# Altium schematic sheet 3_LPDDR4.schdoc(3_LPDDR4)
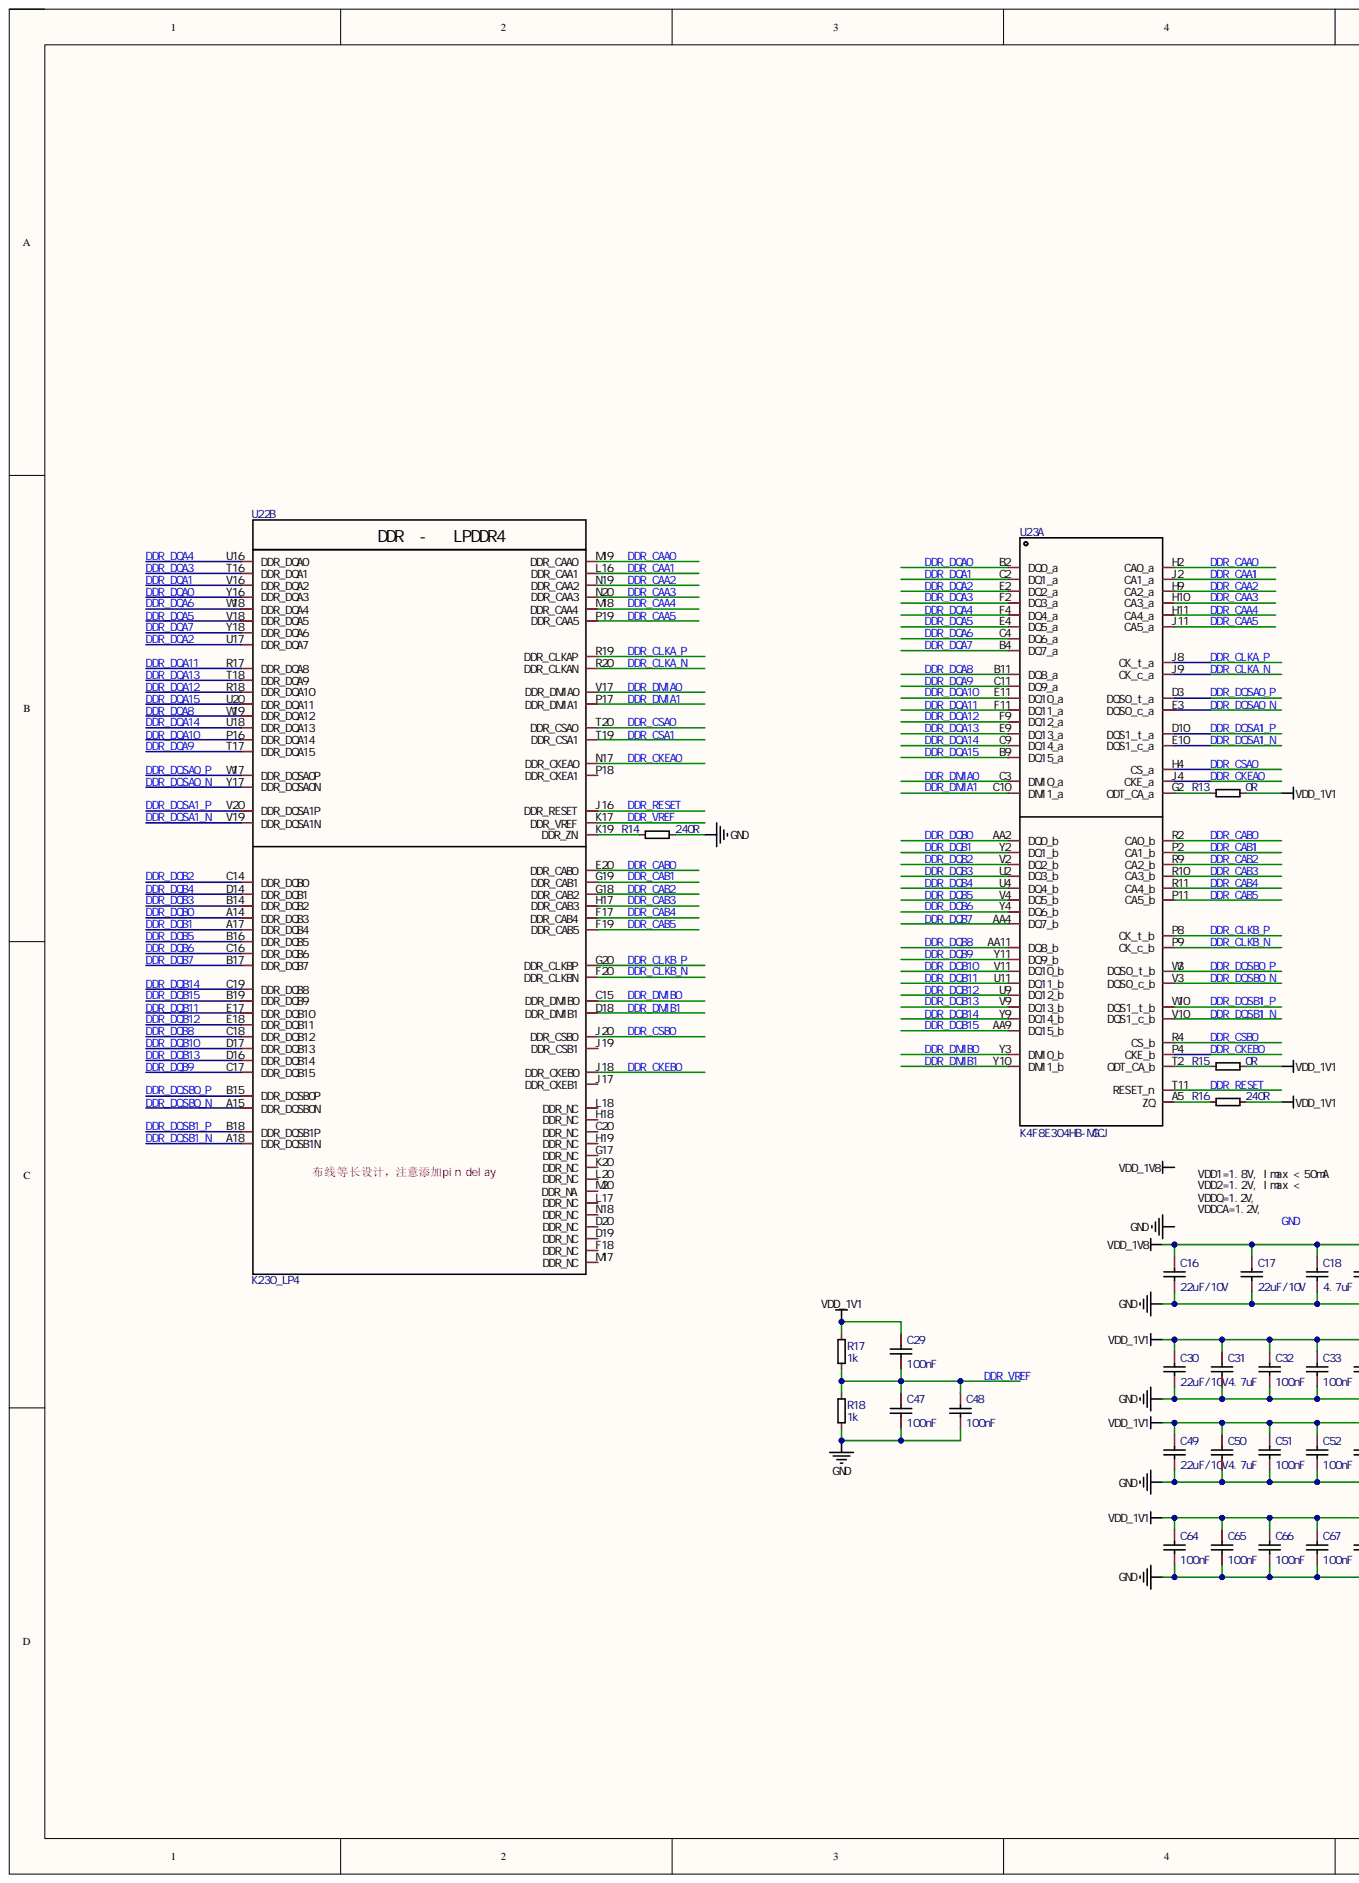
[diagram p0: 3_LPDDR4.schdoc, left]
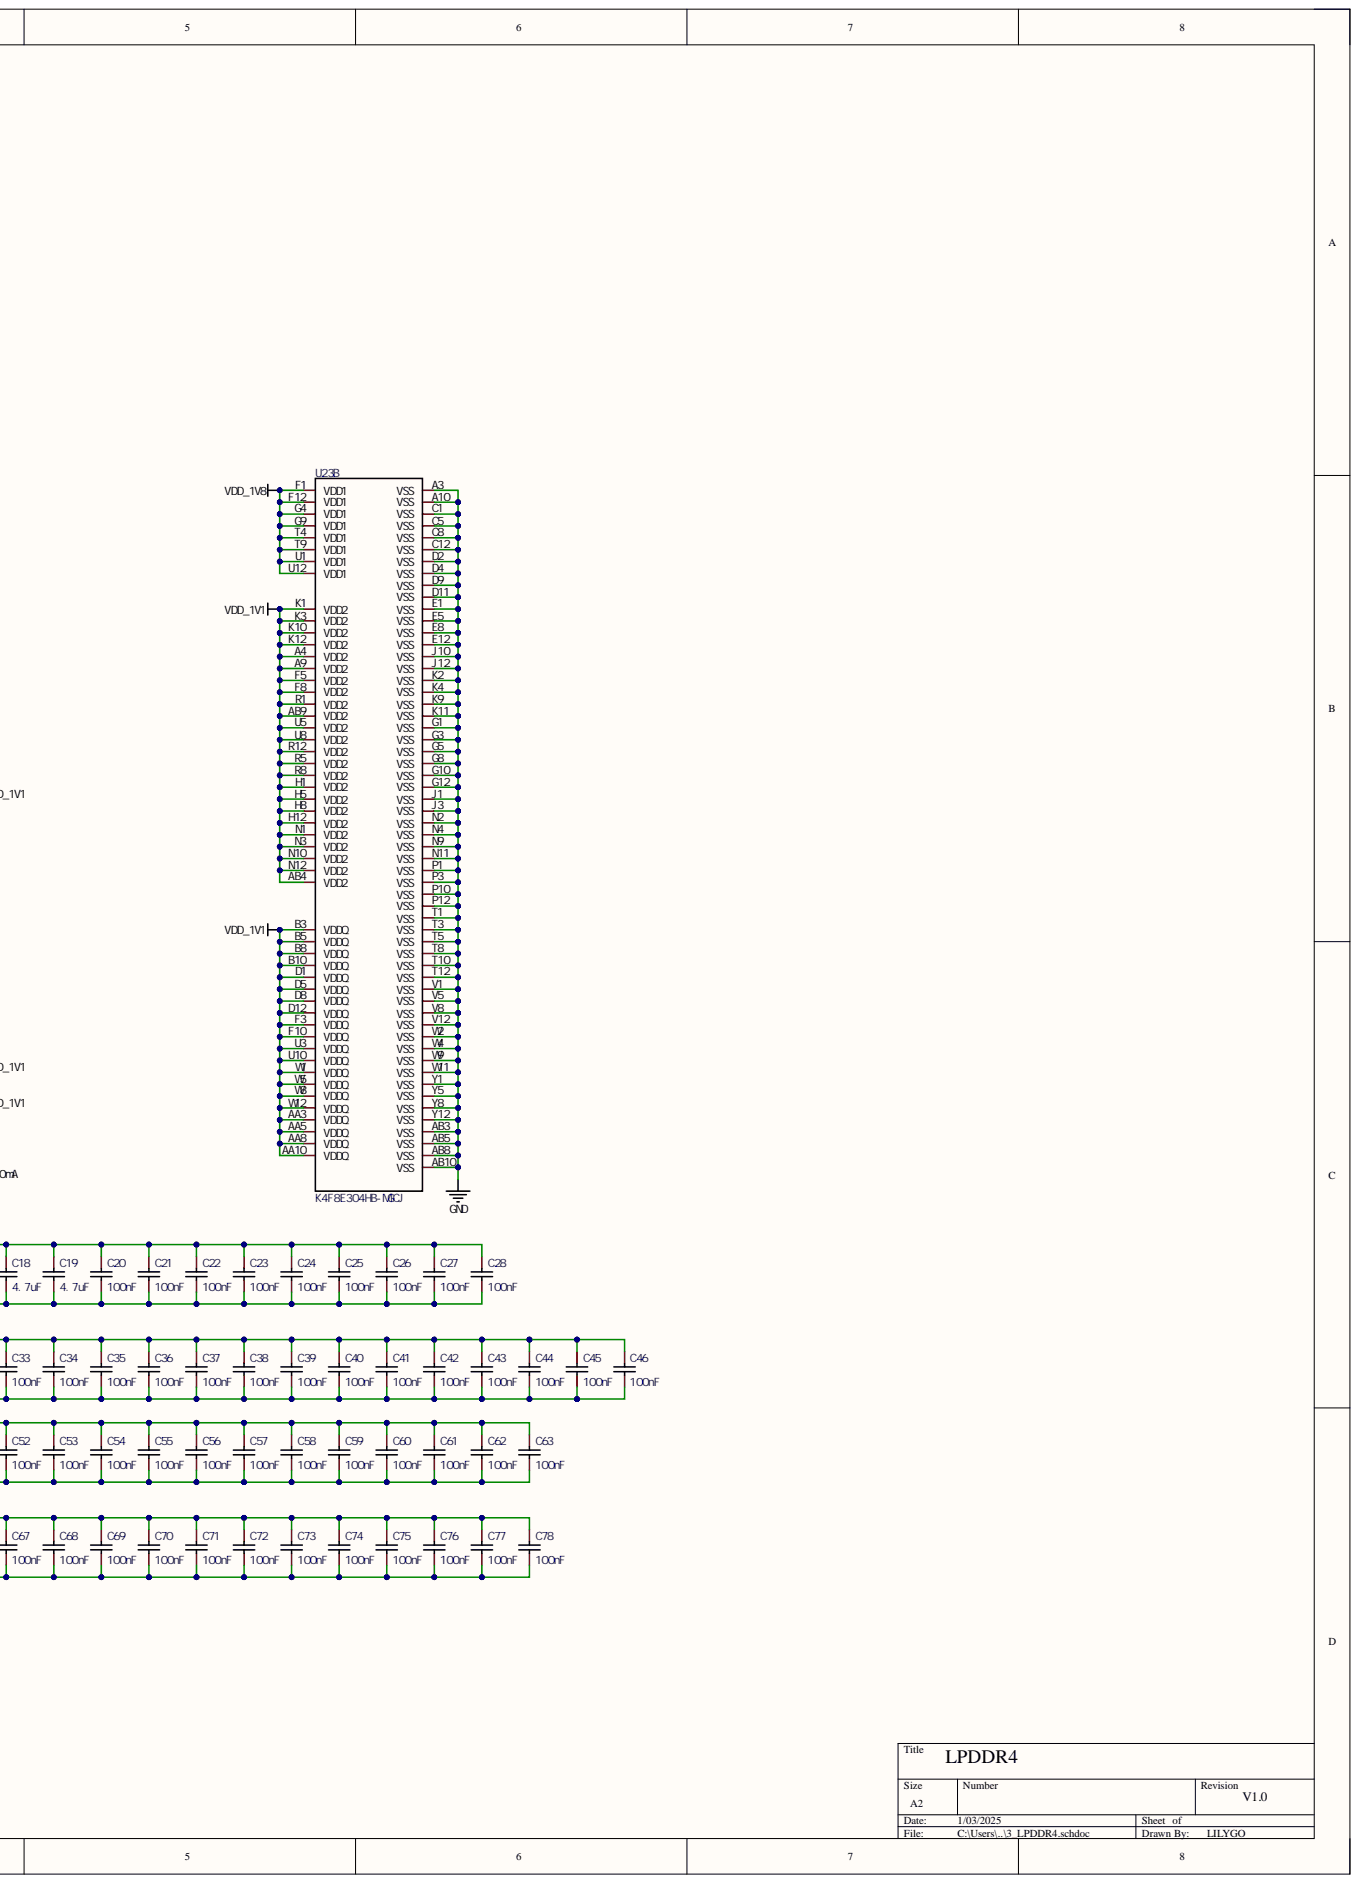
[diagram p1: 3_LPDDR4.schdoc, right]

## Parts
C16  22uF/10V  [c0603]
C17  22uF/10V  [c0603]
C18  4.7uF  [c0402]
C19  4.7uF  [c0402]
C20  100nF  [c0402]
C21  100nF  [c0402]
C22  100nF  [c0402]
C23  100nF  [c0402]
C24  100nF  [c0402]
C25  100nF  [C0201]
C26  100nF  [C0201]
C27  100nF  [C0201]
C28  100nF  [C0201]
C29  100nF  [c0402]
C30  22uF/10V  [c0603]
C31  4.7uF  [c0402]
C32  100nF  [C0201]
C33  100nF  [C0201]
C34  100nF  [C0201]
C35  100nF  [C0201]
C36  100nF  [C0201]
C37  100nF  [C0201]
C38  100nF  [C0201]
C39  100nF  [C0201]
C40  100nF  [C0201]
C41  100nF  [C0201]
C42  100nF  [C0201]
C43  100nF  [C0201]
C44  100nF  [C0201]
C45  100nF  [C0201]
C46  100nF  [C0201]
C47  100nF  [c0402]
C48  100nF  [C0201]
C49  22uF/10V  [c0603]
C50  4.7uF  [c0402]
C51  100nF  [C0201]
C52  100nF  [C0201]
C53  100nF  [C0201]
C54  100nF  [C0201]
C55  100nF  [C0201]
C56  100nF  [C0201]
C57  100nF  [C0201]
C58  100nF  [C0201]
C59  100nF  [C0201]
C60  100nF  [C0201]
C61  100nF  [C0201]
C62  100nF  [C0201]
C63  100nF  [C0201]
C64  100nF  [c0402]
C65  100nF  [c0402]
C66  100nF  [c0402]
C67  100nF  [c0402]
C68  100nF  [c0402]
C69  100nF  [c0402]
C70  100nF  [c0402]
C71  100nF  [c0402]
C72  100nF  [C0201]
C73  100nF  [C0201]
C74  100nF  [C0201]
C75  100nF  [C0201]
C76  100nF  [C0201]
C77  100nF  [C0201]
C78  100nF  [C0201]
R13  0R  [r0402]
R14  240R  [r0402]
R15  0R  [r0402]
R16  240R  [r0402]
R17  1k  [r0402]
R18  1k  [r0402]
U22  K230_LP4  [K230]  (part B)
U23  K4F8E304HB-MGCJ  [BGA-200_L15.0-W10.0_K4F8E304HB-MGCJ]  (parts A,B)

## Nets
VDD_1V1: C29.1 C30.1 C31.1 C32.1 C33.1 C34.1 C35.1 C36.1 C37.1 C38.1 C39.1 C40.1 C41.1 C42.1 C43.1 C44.1 C45.1 C46.1 C49.1 C50.1 C51.1 C52.1 C53.1 C54.1 C55.1 C56.1 C57.1 C58.1 C59.1 C60.1 C61.1 C62.1 C63.1 C64.1 C65.1 C66.1 C67.1 C68.1 C69.1 C70.1 C71.1 C72.1 C73.1 C74.1 C75.1 C76.1 C77.1 C78.1 R13.2 R15.2 R16.2 R17.1 U23.A4(VDD2) U23.A9(VDD2) U23.AA3(VDDQ) U23.AA5(VDDQ) U23.AA8(VDDQ) U23.AA10(VDDQ) U23.AB4(VDD2) U23.AB9(VDD2) U23.B3(VDDQ) U23.B5(VDDQ) U23.B8(VDDQ) U23.B10(VDDQ) U23.D1(VDDQ) U23.D5(VDDQ) U23.D8(VDDQ) U23.D12(VDDQ) U23.F3(VDDQ) U23.F5(VDD2) U23.F8(VDD2) U23.F10(VDDQ) U23.H1(VDD2) U23.H5(VDD2) U23.H8(VDD2) U23.H12(VDD2) U23.K1(VDD2) U23.K3(VDD2) U23.K10(VDD2) U23.K12(VDD2) U23.N1(VDD2) U23.N3(VDD2) U23.N10(VDD2) U23.N12(VDD2) U23.R1(VDD2) U23.R5(VDD2) U23.R8(VDD2) U23.R12(VDD2) U23.U3(VDDQ) U23.U5(VDD2) U23.U8(VDD2) U23.U10(VDDQ) U23.W1(VDDQ) U23.W5(VDDQ) U23.W8(VDDQ) U23.W12(VDDQ)
VDD_1V8: C16.1 C17.1 C18.1 C19.1 C20.1 C21.1 C22.1 C23.1 C24.1 C25.1 C26.1 C27.1 C28.1 U23.F1(VDD1) U23.F12(VDD1) U23.G4(VDD1) U23.G9(VDD1) U23.T4(VDD1) U23.T9(VDD1) U23.U1(VDD1) U23.U12(VDD1)
DDR_CAA0: U22.M19(DDR_CAA0) U23.H2(CA0_a)
DDR_CAA1: U22.L16(DDR_CAA1) U23.J2(CA1_a)
DDR_CAA2: U22.N19(DDR_CAA2) U23.H9(CA2_a)
DDR_CAA3: U22.N20(DDR_CAA3) U23.H10(CA3_a)
DDR_CAA4: U22.M18(DDR_CAA4) U23.H11(CA4_a)
DDR_CAA5: U22.P19(DDR_CAA5) U23.J11(CA5_a)
DDR_CAB0: U22.E20(DDR_CAB0) U23.R2(CA0_b)
DDR_CAB1: U22.G19(DDR_CAB1) U23.P2(CA1_b)
DDR_CAB2: U22.G18(DDR_CAB2) U23.R9(CA2_b)
DDR_CAB3: U22.H17(DDR_CAB3) U23.R10(CA3_b)
DDR_CAB4: U22.F17(DDR_CAB4) U23.R11(CA4_b)
DDR_CAB5: U22.F19(DDR_CAB5) U23.P11(CA5_b)
DDR_CKEA0: U22.N17(DDR_CKEA0) U23.J4(CKE_a)
DDR_CKEB0: U22.J18(DDR_CKEB0) U23.P4(CKE_b)
DDR_CLKA_N: U22.R20(DDR_CLKAN) U23.J9(CK_c_a)
DDR_CLKA_P: U22.R19(DDR_CLKAP) U23.J8(CK_t_a)
DDR_CLKB_N: U22.F20(DDR_CLKBN) U23.P9(CK_c_b)
DDR_CLKB_P: U22.G20(DDR_CLKBP) U23.P8(CK_t_b)
DDR_CSA0: U22.T20(DDR_CSA0) U23.H4(CS_a)
DDR_CSA1: U22.T19(DDR_CSA1)
DDR_CSB0: U22.J20(DDR_CSB0) U23.R4(CS_b)
DDR_DMIA0: U22.V17(DDR_DMIA0) U23.C3(DMIO_a)
DDR_DMIA1: U22.P17(DDR_DMIA1) U23.C10(DMI1_a)
DDR_DMIB0: U22.C15(DDR_DMIB0) U23.Y3(DMI0_b)
DDR_DMIB1: U22.D18(DDR_DMIB1) U23.Y10(DMI1_b)
DDR_DQA0: U22.Y16(DDR_DQA3) U23.B2(DQ0_a)
DDR_DQA1: U22.V16(DDR_DQA2) U23.C2(DQ1_a)
DDR_DQA2: U22.U17(DDR_DQA7) U23.E2(DQ2_a)
DDR_DQA3: U22.T16(DDR_DQA1) U23.F2(DQ3_a)
DDR_DQA4: U22.U16(DDR_DQA0) U23.F4(DQ4_a)
DDR_DQA5: U22.V18(DDR_DQA5) U23.E4(DQ5_a)
DDR_DQA6: U22.W18(DDR_DQA4) U23.C4(DQ6_a)
DDR_DQA7: U22.Y18(DDR_DQA6) U23.B4(DQ7_a)
DDR_DQA8: U22.W19(DDR_DQA12) U23.B11(DQ8_a)
DDR_DQA9: U22.T17(DDR_DQA15) U23.C11(DQ9_a)
DDR_DQA10: U22.P16(DDR_DQA14) U23.E11(DQ10_a)
DDR_DQA11: U22.R17(DDR_DQA8) U23.F11(DQ11_a)
DDR_DQA12: U22.R18(DDR_DQA10) U23.F9(DQ12_a)
DDR_DQA13: U22.T18(DDR_DQA9) U23.E9(DQ13_a)
DDR_DQA14: U22.U18(DDR_DQA13) U23.C9(DQ14_a)
DDR_DQA15: U22.U20(DDR_DQA11) U23.B9(DQ15_a)
DDR_DQB0: U22.A14(DDR_DQB3) U23.AA2(DQ0_b)
DDR_DQB1: U22.A17(DDR_DQB4) U23.Y2(DQ1_b)
DDR_DQB2: U22.C14(DDR_DQB0) U23.V2(DQ2_b)
DDR_DQB3: U22.B14(DDR_DQB2) U23.U2(DQ3_b)
DDR_DQB4: U22.D14(DDR_DQB1) U23.U4(DQ4_b)
DDR_DQB5: U22.B16(DDR_DQB5) U23.V4(DQ5_b)
DDR_DQB6: U22.C16(DDR_DQB6) U23.Y4(DQ6_b)
DDR_DQB7: U22.B17(DDR_DQB7) U23.AA4(DQ7_b)
DDR_DQB8: U22.C18(DDR_DQB12) U23.AA11(DQ8_b)
DDR_DQB9: U22.C17(DDR_DQB15) U23.Y11(DQ9_b)
DDR_DQB10: U22.D17(DDR_DQB13) U23.V11(DQ10_b)
DDR_DQB11: U22.E17(DDR_DQB10) U23.U11(DQ11_b)
DDR_DQB12: U22.E18(DDR_DQB11) U23.U9(DQ12_b)
DDR_DQB13: U22.D16(DDR_DQB14) U23.V9(DQ13_b)
DDR_DQB14: U22.C19(DDR_DQB8) U23.Y9(DQ14_b)
DDR_DQB15: U22.B19(DDR_DQB9) U23.AA9(DQ15_b)
DDR_DQSA0_N: U22.Y17(DDR_DQSA0N) U23.E3(DQS0_c_a)
DDR_DQSA0_P: U22.W17(DDR_DQSA0P) U23.D3(DQS0_t_a)
DDR_DQSA1_N: U22.V19(DDR_DQSA1N) U23.E10(DQS1_c_a)
DDR_DQSA1_P: U22.V20(DDR_DQSA1P) U23.D10(DQS1_t_a)
DDR_DQSB0_N: U22.A15(DDR_DQSB0N) U23.V3(DQS0_c_b)
DDR_DQSB0_P: U22.B15(DDR_DQSB0P) U23.W3(DQS0_t_b)
DDR_DQSB1_N: U22.A18(DDR_DQSB1N) U23.V10(DQS1_c_b)
DDR_DQSB1_P: U22.B18(DDR_DQSB1P) U23.W10(DQS1_t_b)
DDR_RESET: U22.J16(DDR_RESET) U23.T11(RESET_n)
DDR_VREF: C29.2 C47.1 C48.1 R17.2 R18.1 U22.K17(DDR_VREF)
GND: C16.2 C17.2 C18.2 C19.2 C20.2 C21.2 C22.2 C23.2 C24.2 C25.2 C26.2 C27.2 C28.2 C30.2 C31.2 C32.2 C33.2 C34.2 C35.2 C36.2 C37.2 C38.2 C39.2 C40.2 C41.2 C42.2 C43.2 C44.2 C45.2 C46.2 C47.2 C48.2 C49.2 C50.2 C51.2 C52.2 C53.2 C54.2 C55.2 C56.2 C57.2 C58.2 C59.2 C60.2 C61.2 C62.2 C63.2 C64.2 C65.2 C66.2 C67.2 C68.2 C69.2 C70.2 C71.2 C72.2 C73.2 C74.2 C75.2 C76.2 C77.2 C78.2 R14.2 R18.2 U23.A3(VSS) U23.A10(VSS) U23.AB3(VSS) U23.AB5(VSS) U23.AB8(VSS) U23.AB10(VSS) U23.C1(VSS) U23.C5(VSS) U23.C8(VSS) U23.C12(VSS) U23.D2(VSS) U23.D4(VSS) U23.D9(VSS) U23.D11(VSS) U23.E1(VSS) U23.E5(VSS) U23.E8(VSS) U23.E12(VSS) U23.G1(VSS) U23.G3(VSS) U23.G5(VSS) U23.G8(VSS) U23.G10(VSS) U23.G12(VSS) U23.J1(VSS) U23.J3(VSS) U23.J10(VSS) U23.J12(VSS) U23.K2(VSS) U23.K4(VSS) U23.K9(VSS) U23.K11(VSS) U23.N2(VSS) U23.N4(VSS) U23.N9(VSS) U23.N11(VSS) U23.P1(VSS) U23.P3(VSS) U23.P10(VSS) U23.P12(VSS) U23.T1(VSS) U23.T3(VSS) U23.T5(VSS) U23.T8(VSS) U23.T10(VSS) U23.T12(VSS) U23.V1(VSS) U23.V5(VSS) U23.V8(VSS) U23.V12(VSS) U23.W2(VSS) U23.W4(VSS) U23.W9(VSS) U23.W11(VSS) U23.Y1(VSS) U23.Y5(VSS) U23.Y8(VSS) U23.Y12(VSS)
NetR13_1: R13.1 U23.G2(ODT_CA_a)
NetR14_1: R14.1 U22.K19(DDR_ZN)
NetR15_1: R15.1 U23.T2(ODT_CA_b)
NetR16_1: R16.1 U23.A5(ZQ)

Not connected: U22 C20(DDR_NC) D19(DDR_NC) D20(DDR_NC) F18(DDR_NC) G17(DDR_NC) H18(DDR_NC) H19(DDR_NC) J17(DDR_CKEB1) J19(DDR_CSB1) K20(DDR_NC) L17(DDR_NC) L18(DDR_NC) L20(DDR_NC) M17(DDR_NC) M20(DDR_NA) N18(DDR_NC) P18(DDR_CKEA1)

## Library data of the parts
C16-C78 = CAP: Add into BOM: yes; Component Kind: Standard; Convert to PCB: yes; Device: CAP; Symbol: CAP
  C16 C17 C30 C49: Comment_1: 22uF/10V
  C18 C19 C31 C50: Comment_1: 4.7uF
  C20-C29 C32-C48 C51-C78: Comment_1: 100nF
R13-R18 = RES: Add into BOM: yes; Component Kind: Standard; Convert to PCB: yes; Device: RES; Symbol: RES
  R13 R15: Comment_1: 0R
  R14 R16: Comment_1: 240R
  R17 R18: Comment_1: 1k
U22 = K230_LP4: Add into BOM: yes; Comment_1: K230_LP4; Component Kind: Standard; Convert to PCB: yes; Device: K230_LP4; Library Name: CanMV-K230-LP4-V3.0.SCHLIB; PCB3D: K230; Symbol: K230_LP4
U23 = K4F8E304HB-MGCJ: LPDDR4-x32; Add into BOM: yes; Comment_1: K4F8E304HB-MGCJ; Component Kind: Standard; Convert to PCB: yes; Device: K4F8E304HB-MGCJ; JLCPCB Part Class: Extended Part; LCSC Part Name: K4F8E304HB-MGCJ; PCB3D: BGA-200_L15.0-W10.0_K4F8E304HB-MGCJ; Supplier: LCSC; Supplier Footprint: TFBGA-200; Supplier Part: C2803257; Symbol: K4F8E304HB-MGCJ
